# Altium schematic sheet eMMC, QSPI Flash.SchDoc
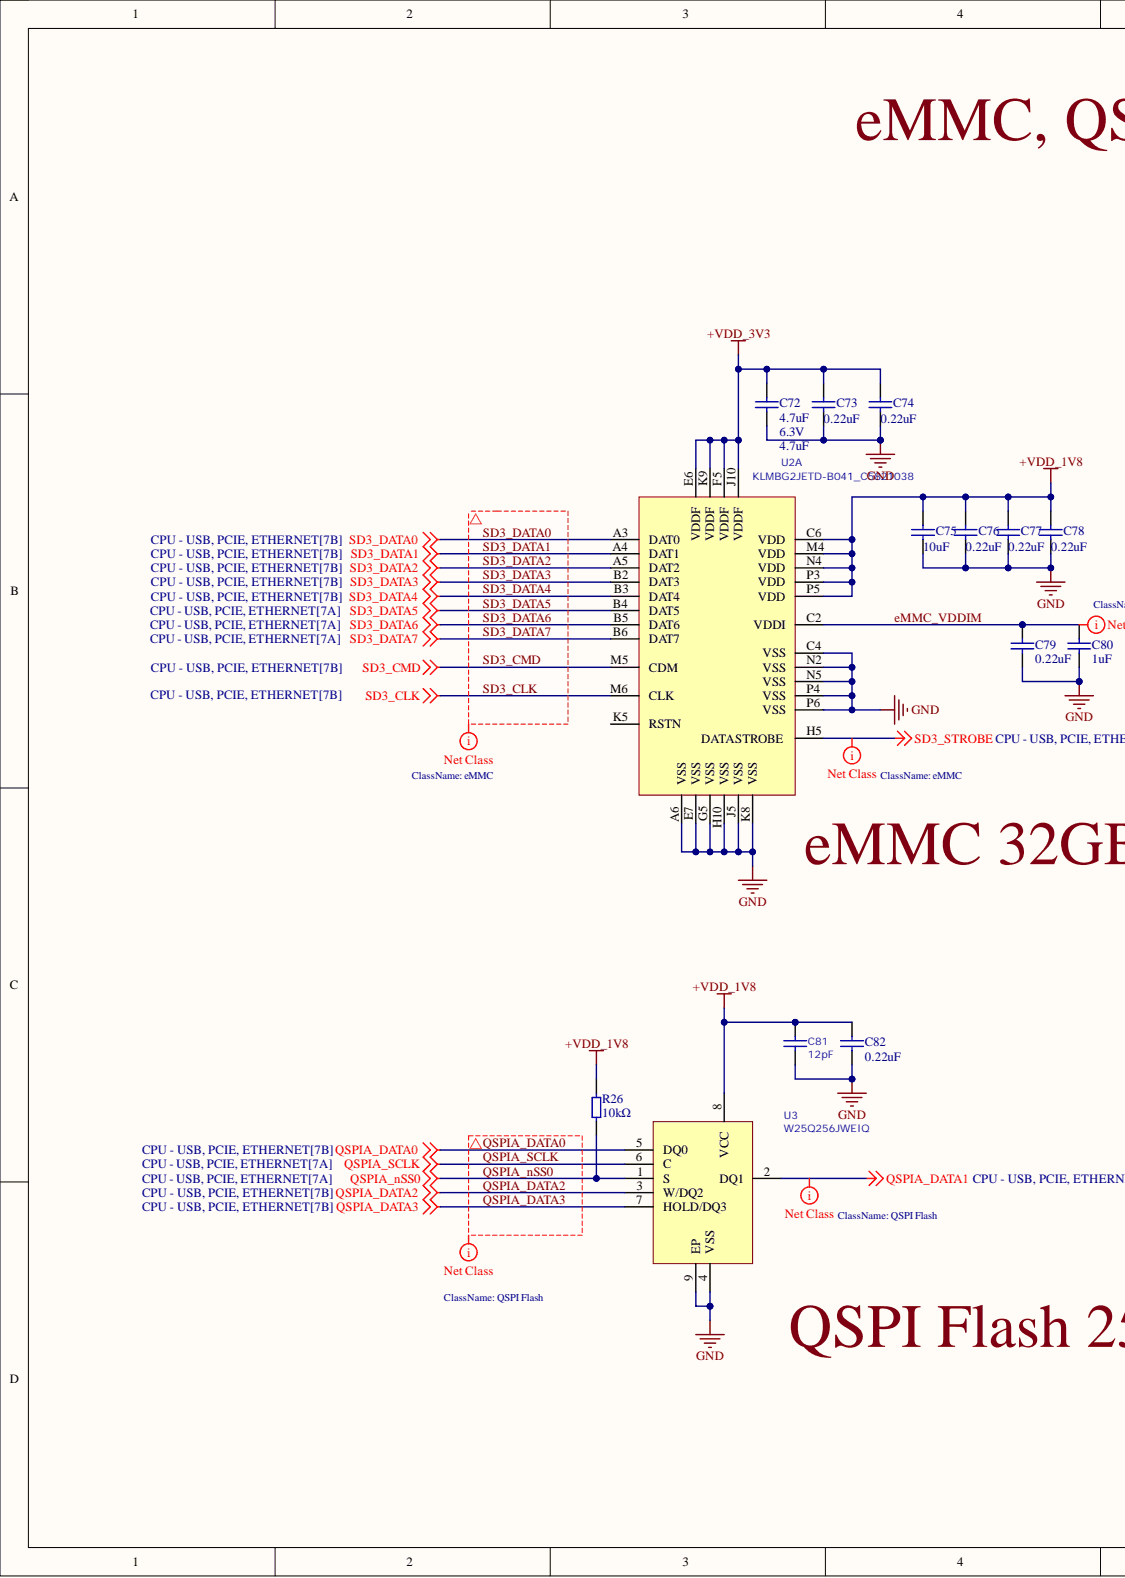
[diagram p0: eMMC, QSPI Flash.SchDoc, left]
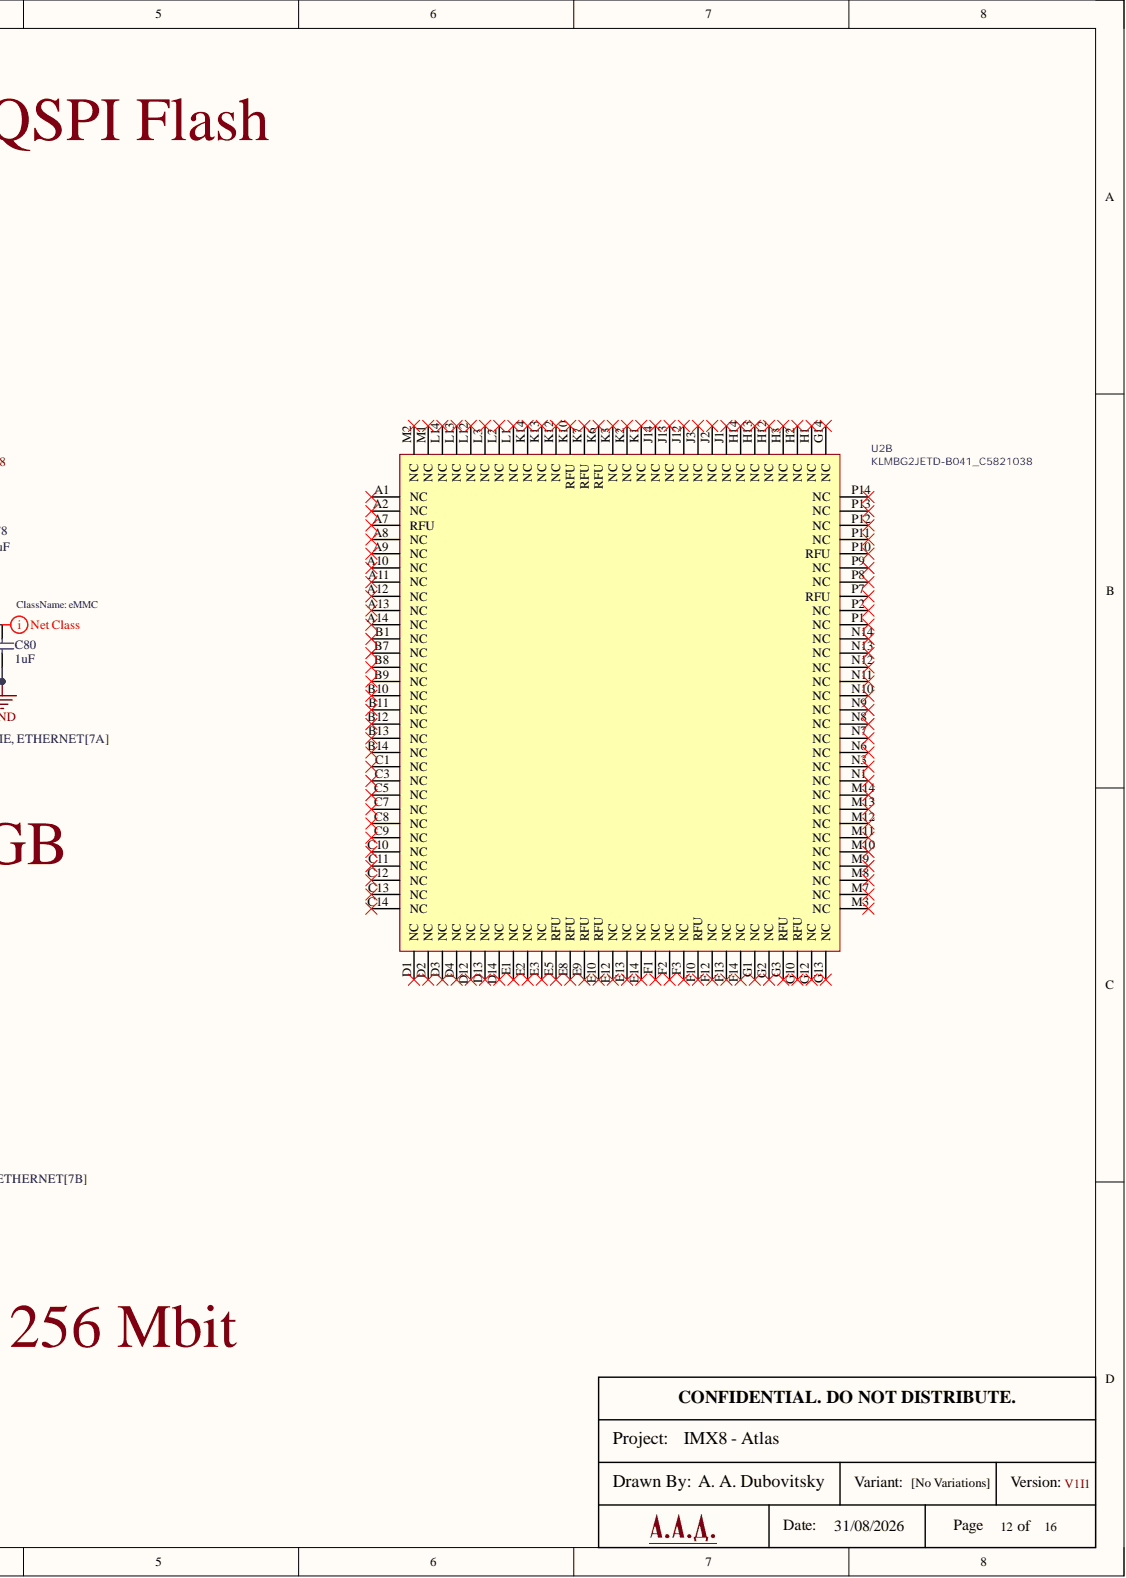
[diagram p1: eMMC, QSPI Flash.SchDoc, right]

## Parts
C72  4.7uF  V: 6.3V  [CAP 0402_1005]
C73  0.22uF  [CAP 0201_0603 MINI]
C74  0.22uF  [CAP 0201_0603 MINI]
C75  10uF  [CAP 0402_1005]
C76  0.22uF  [CAP 0201_0603 MINI]
C77  0.22uF  [CAP 0201_0603 MINI]
C78  0.22uF  [CAP 0201_0603 MINI]
C79  0.22uF  [CAP 0201_0603 MINI]
C80  1uF  [CAP 0201_0603 MINI]
C81  12pF  [CAP 0201_0603 MINI]
C82  0.22uF  [CAP 0201_0603 MINI]
R26  10kΩ  [RES 0201_0603]
U2  KLMBG2JETD-B041_C5821038  [FBGA-153_L13.0-W11.5-P0.50_KLMAG1JETD-B041]  (parts A,B)
U3  W25Q256JWEIQ  [WSON-8_L8.0-W6.0-P1.27-TL-EP]

## Nets
+VDD_1V8: C75.2 C76.2 C77.2 C78.2 C81.2 C82.2 R26.1 U2.C6(VDD) U2.M4(VDD) U2.N4(VDD) U2.P3(VDD) U2.P5(VDD) U3.8(VCC)
+VDD_3V3: C72.2 C73.2 C74.2 U2.E6(VDDF) U2.F5(VDDF) U2.J10(VDDF) U2.K9(VDDF)
GND: C72.1 C73.1 C74.1 C75.1 C76.1 C77.1 C78.1 C79.1 C80.1 C81.1 C82.1 U2.A6(VSS) U2.C4(VSS) U2.E7(VSS) U2.G5(VSS) U2.H10(VSS) U2.J5(VSS) U2.K8(VSS) U2.N2(VSS) U2.N5(VSS) U2.P4(VSS) U2.P6(VSS) U3.4(VSS) U3.9(EP)
QSPIA_DATA1: U3.2(DQ1)
SD3_STROBE: U2.H5(DATASTROBE)
eMMC_VDDIM: C79.2 C80.2 U2.C2(VDDI)
QSPIA_DATA0: U3.5(DQ0)
QSPIA_DATA2: U3.3(W/DQ2)
QSPIA_DATA3: U3.7(HOLD/DQ3)
QSPIA_nSS0: R26.2 U3.1(S)
QSPIA_SCLK: U3.6(C)
SD3_CLK: U2.M6(CLK)
SD3_CMD: U2.M5(CDM)
SD3_DATA0: U2.A3(DAT0)
SD3_DATA1: U2.A4(DAT1)
SD3_DATA2: U2.A5(DAT2)
SD3_DATA3: U2.B2(DAT3)
SD3_DATA4: U2.B3(DAT4)
SD3_DATA5: U2.B4(DAT5)
SD3_DATA6: U2.B5(DAT6)
SD3_DATA7: U2.B6(DAT7)

Not connected: U2 A1(NC) A2(NC) A7(RFU) A8(NC) A9(NC) A10(NC) A11(NC) A12(NC) A13(NC) A14(NC) B1(NC) B7(NC) B8(NC) B9(NC) B10(NC) B11(NC) B12(NC) B13(NC) B14(NC) C1(NC) C3(NC) C5(NC) C7(NC) C8(NC) C9(NC) C10(NC) C11(NC) C12(NC) C13(NC) C14(NC) D1(NC) D2(NC) D3(NC) D4(NC) D12(NC) D13(NC) D14(NC) E1(NC) E2(NC) E3(NC) E5(RFU) E8(RFU) E9(RFU) E10(RFU) E12(NC) E13(NC) E14(NC) F1(NC) F2(NC) F3(NC) F10(RFU) F12(NC) F13(NC) F14(NC) G1(NC) G2(NC) G3(RFU) G10(RFU) G12(NC) G13(NC) G14(NC) H1(NC) H2(NC) H3(NC) H12(NC) H13(NC) H14(NC) J1(NC) J2(NC) J3(NC) J12(NC) J13(NC) J14(NC) K1(NC) K2(NC) K3(NC) K5(RSTN) K6(RFU) K7(RFU) K10(RFU) K12(NC) K13(NC) K14(NC) L1(NC) L2(NC) L3(NC) L12(NC) L13(NC) L14(NC) M1(NC) M2(NC) M3(NC) M7(NC) M8(NC) M9(NC) M10(NC) M11(NC) M12(NC) M13(NC) M14(NC) N1(NC) N3(NC) N6(NC) N7(NC) N8(NC) N9(NC) N10(NC) N11(NC) N12(NC) N13(NC) N14(NC) P1(NC) P2(NC) P7(RFU) P8(NC) P9(NC) P10(RFU) P11(NC) P12(NC) P13(NC) P14(NC)

## Library data of the parts
C72 = GRM155R60J475ME87D: Cap. 4.7uF 6.3V ±20%; C: 4.7uF; Component Kind: Standard; Library Name: Atlas - Symbols.SchLib
C73 C74 C76-C79 C82 = C76932: Cap. 0.22uF 6.3V ±20% 0201; Component Kind: Standard; Library Name: Atlas - Symbols.SchLib
C75 = C2846677: Cap. 10uF 10V ±20% 0402; Component Kind: Standard; Library Name: Atlas - Symbols.SchLib
C80 = C6119758: Cap. 1uF 10V ±20% 0201; Component Kind: Standard; Library Name: Atlas - Symbols.SchLib
C81 = C384960: Cap. 12pF 50V ±1% 0201; Component Kind: Standard; Library Name: Atlas - Symbols.SchLib
R26 = C138117: Res. 10kΩ 25V 50mW ±5% 0201; Component Kind: Standard; Library Name: Atlas - Symbols.SchLib
U3 = C2940048: 256Mbit  NOR FLASH; Component Kind: Standard; Library Name: Atlas - Symbols.SchLib
U2 = KLMBG2JETD-B041_C5821038: Component Kind: Standard; Library Name: Atlas - Symbols.SchLib
